# Altium schematic sheet SD_Card.SchDoc
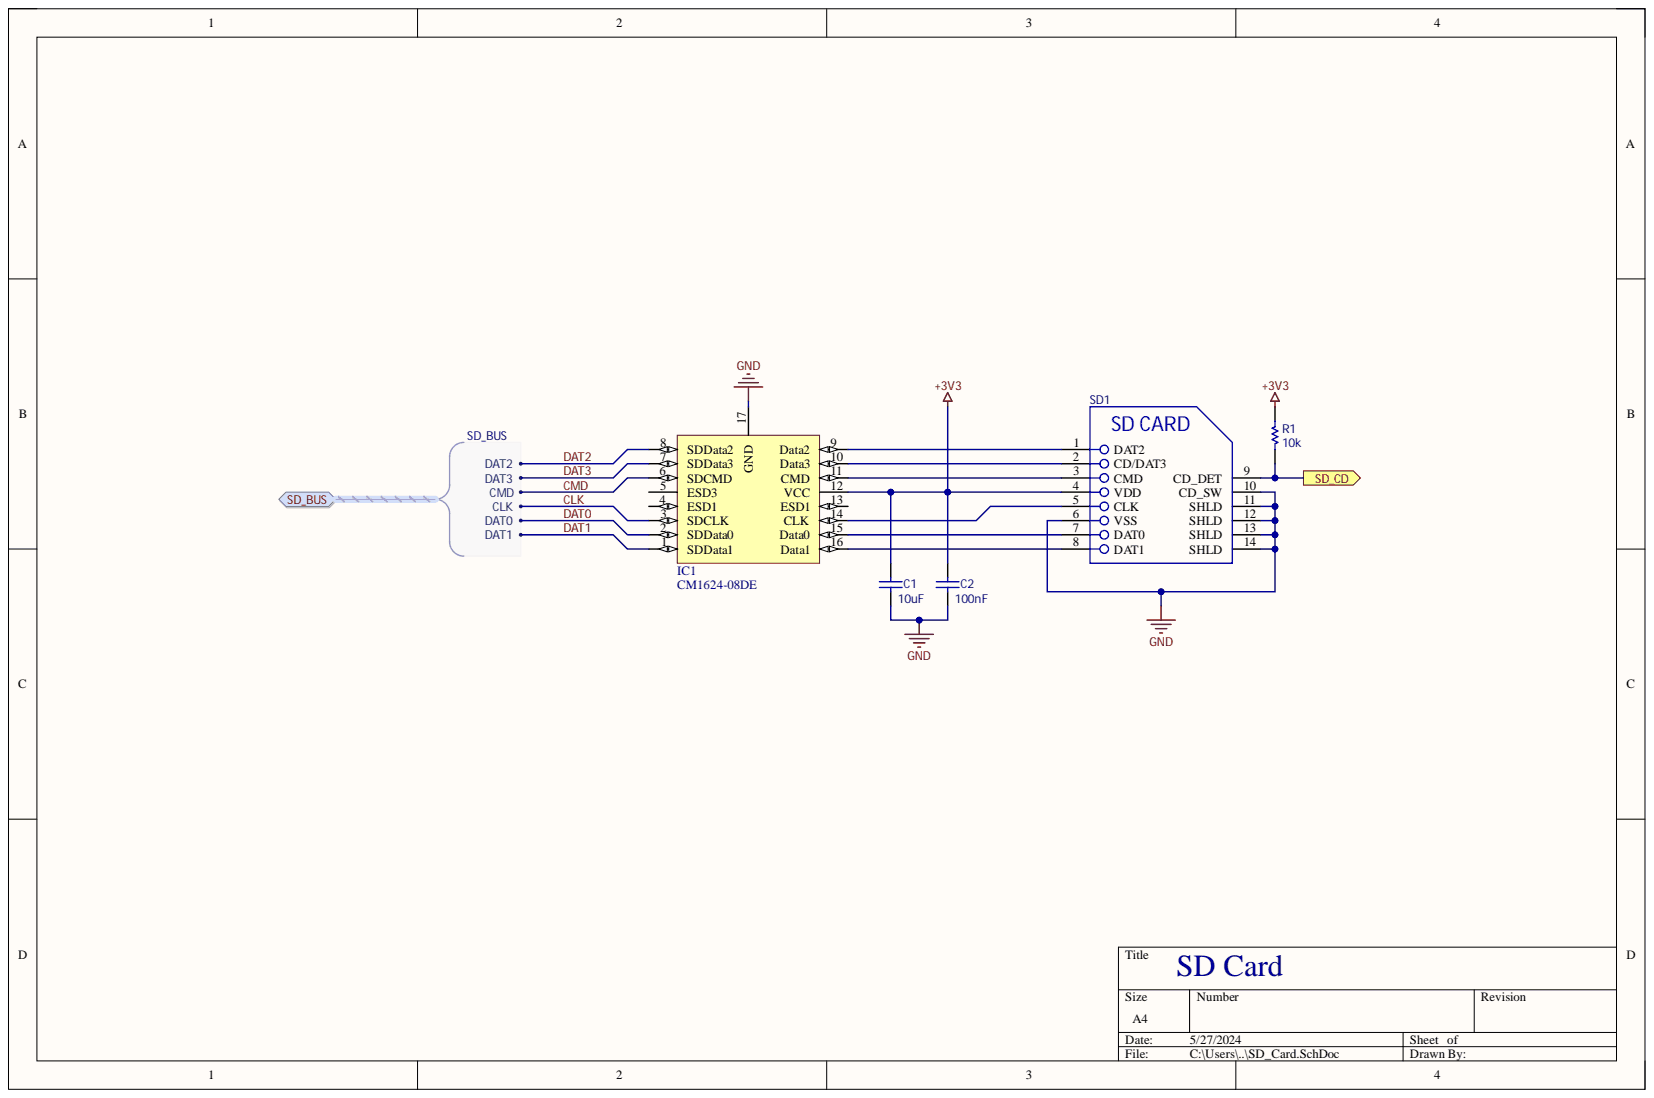
[diagram p0: SD_Card.SchDoc]

## Parts
C1  value 10uF  Capacitance: 10uF  [CAPC2012X14N]  (hidden comment: C0805C106K8PACTU)
C2  value 100nF  Capacitance: 100nF  [CAPC1608X10N]  (hidden comment: C0603C104M5RACTU)
IC1  CM1624-08DE  [SON40P140X55_HS-17N]
R1  value 10k  [RESC1608X06N]  (hidden comment: CRCW060310K0FKEA)
SD1  5027740891  [5027740891-Footprint-1]  (value not drawn)

## Ports
SD_BUS, SD_BUS.CLK, SD_BUS.CMD, SD_BUS.DAT0, SD_BUS.DAT1, SD_BUS.DAT2, SD_BUS.DAT3, SD_CD

## Nets
+3V3: C1.2 C2.2 IC1.12(VCC) R1.2 SD1.4
GND: C1.1 C2.1 IC1.17(GND) SD1.6 SD1.10(CD_SW) SD1.11(SHLD) SD1.12(SHLD) SD1.13(SHLD) SD1.14(SHLD)
CLK: IC1.3(SDCLK) ; port SD_BUS
CMD: IC1.6(SDCMD) ; port SD_BUS
DAT0: IC1.2(SDData0) ; port SD_BUS
DAT1: IC1.1(SDData1) ; port SD_BUS
DAT2: IC1.8(SDData2) ; port SD_BUS
DAT3: IC1.7(SDData3) ; port SD_BUS
SD_CD: R1.1 SD1.9(CD_DET) ; port SD_CD
NetIC1_4: IC1.4(ESD1)
NetIC1_5: IC1.5(ESD3)
NetIC1_9: IC1.9(Data2) SD1.1
NetIC1_10: IC1.10(Data3) SD1.2
NetIC1_11: IC1.11(CMD) SD1.3
NetIC1_13: IC1.13(ESD1)
NetIC1_14: IC1.14(CLK) SD1.5
NetIC1_15: IC1.15(Data0) SD1.7
NetIC1_16: IC1.16(Data1) SD1.8

## Library data of the parts
C1 = CMP-00046-3: CAP CER 10UF 10V X5R 0805; Dielectric Material: Ceramic; Dissipation Factor: 10%; Case Code (Imperial): 0805; Case Code (Metric): 2012; Case/Package: 0805; Component Kind: Standard; Contact Plating: Tin,Matte; Depth: 1.25mm; Dielectric: X5R; Height: 1.25mm; JLC_PN: C15850; Length: 2mm; Max Operating Temperature: 85°C; Min Operating Temperature: -55°C; Mount: Surface Mount; Number of Pins: 2; Package Quantity: 1; Packaging: Tape and Reel; Radiation Hardening: No; REACH SVHC: No SVHC; Termination: SMD/SMT; Thickness: 0.055inch; Tolerance: 10%; Voltage: 10V; Voltage Rating: 10V; Voltage Rating (DC): 10V; Width: 1.2mm; Library Name: UWA Aerospace
C2 = CMP-00038-5: Cap Ceramic 0.1uF 50V X7R 20% SMD 0603 125C T/R; Dissipation Factor: 2.5%; Case Code (Imperial): 0603; Case Code (Metric): 1608; Case/Package: 0603; Component Kind: Standard; Contact Plating: Tin,Matte; Depth: 0.8mm; Dielectric: X7R; Dielectric Material: Ceramic; Height: 0.8mm; JLC_PN: C14663; Lead Pitch: 0.7mm; Length: 1.6mm; Max Operating Temperature: 125°C; Min Operating Temperature: -55°C; Mount: Surface Mount,PCB; Package Quantity: 1; Packaging: Tape and Reel; Radiation Hardening: No; REACH SVHC: No SVHC; Termination: SMD/SMT; Thickness: 0.034inch; Tolerance: 20%; Voltage Rating: 50V; Voltage Rating (DC): 50V; Width: 0.031inch; Library Name: UWA Aerospace
IC1 = CMP-00015-3: EMI Filter Flat Style SMD T/R; Capacitance: 20pF; Clamping Voltage: 9V; DC Resistance (DCR): 40R; Direction: Bidirectional; ESD Protection: Yes; Number of Channels: 6; Number of Lines: 8; Operating Supply Voltage: 5V; Case/Package: DFN; Component Kind: Standard; Contact Plating: Tin,Matte; Depth: 1.45mm; Halogen Free: Halogen Free; Height: 0.022inch; Lead Free: Lead Free; Length: 3.4mm; Max Operating Temperature: 85°C; Min Operating Temperature: -40°C; Number of Pins: 16; Package Quantity: 1; Packaging: Tape and Reel; REACH SVHC: No SVHC; Termination: Flat; Width: 1.35mm; Library Name: UWA Aerospace
R1 = CMP-00042-5: VISHAY - CRCW060310K0FKEA - SMD Chip Resistor, 0603 [1608 Metric], 10 kohm, CRCW e3 Series, 75 V, Thick Film, 100 mW; Max Power Dissipation: 100mW; Resistance: 10kR; Temperature Coefficient: 100ppm/°C; Tolerance: 1%; Voltage Rating: 75V; Case Code (Imperial): 0603; Case Code (Metric): 1608; Case/Package: 0603; Component Kind: Standard; Composition: Thick Film; Contact Plating: Tin,Lead; Depth: 0.85mm; Features: Automotive AEC-Q200; Height: 0.45mm; JLC_PN: C25804; Lead Free: Lead Free; Length: 1.55mm; Max Operating Temperature: 155°C; Military Standard: Not; Min Operating Temperature: -55°C; Number of Pins: 2; Number of Terminations: 2; Package Quantity: 10; Packaging: Tape and Reel; Power Rating: 100mW; Radiation Hardening: No; REACH SVHC: Unknown; Termination: SMD/SMT; Voltage Rating (DC): 75V; Weight: 7.1E-05oz; Width: 0.033inch; Library Name: UWA Aerospace
SD1 = CMP-00007-2: MOLEX   502774-0891.   MEMORY CARD CONNECTOR, MICROSD, 8POS; Current Rating: 500mA; Gender: Male; Max Voltage Rating (DC): 1.01kV; Number of Circuits: 8; Pitch: 1.1mm; Voltage Rating (AC): 10V; Component Kind: Standard; Connector Type: Connector; Contact Material: Copper Alloy,Copper; Contact Plating: Gold; Depth: 16mm; Halogen Free: Low Halogen; Height: 1.8mm; Housing Material: Polymer; Length: 14.6mm; Max Operating Temperature: 85°C; Min Operating Temperature: -25°C; Mount: Surface Mount,Reverse; Number of Contacts: 8; Number of Rows: 1; Orientation: Right Angle; Package Quantity: 1; Packaging: Tape and Reel; Radiation Hardening: No; REACH SVHC: Unknown; Termination: Solder; Width: 1.8mm; Library Name: UWA Aerospace
